# Altium schematic sheet ECU_Comm.SchDoc
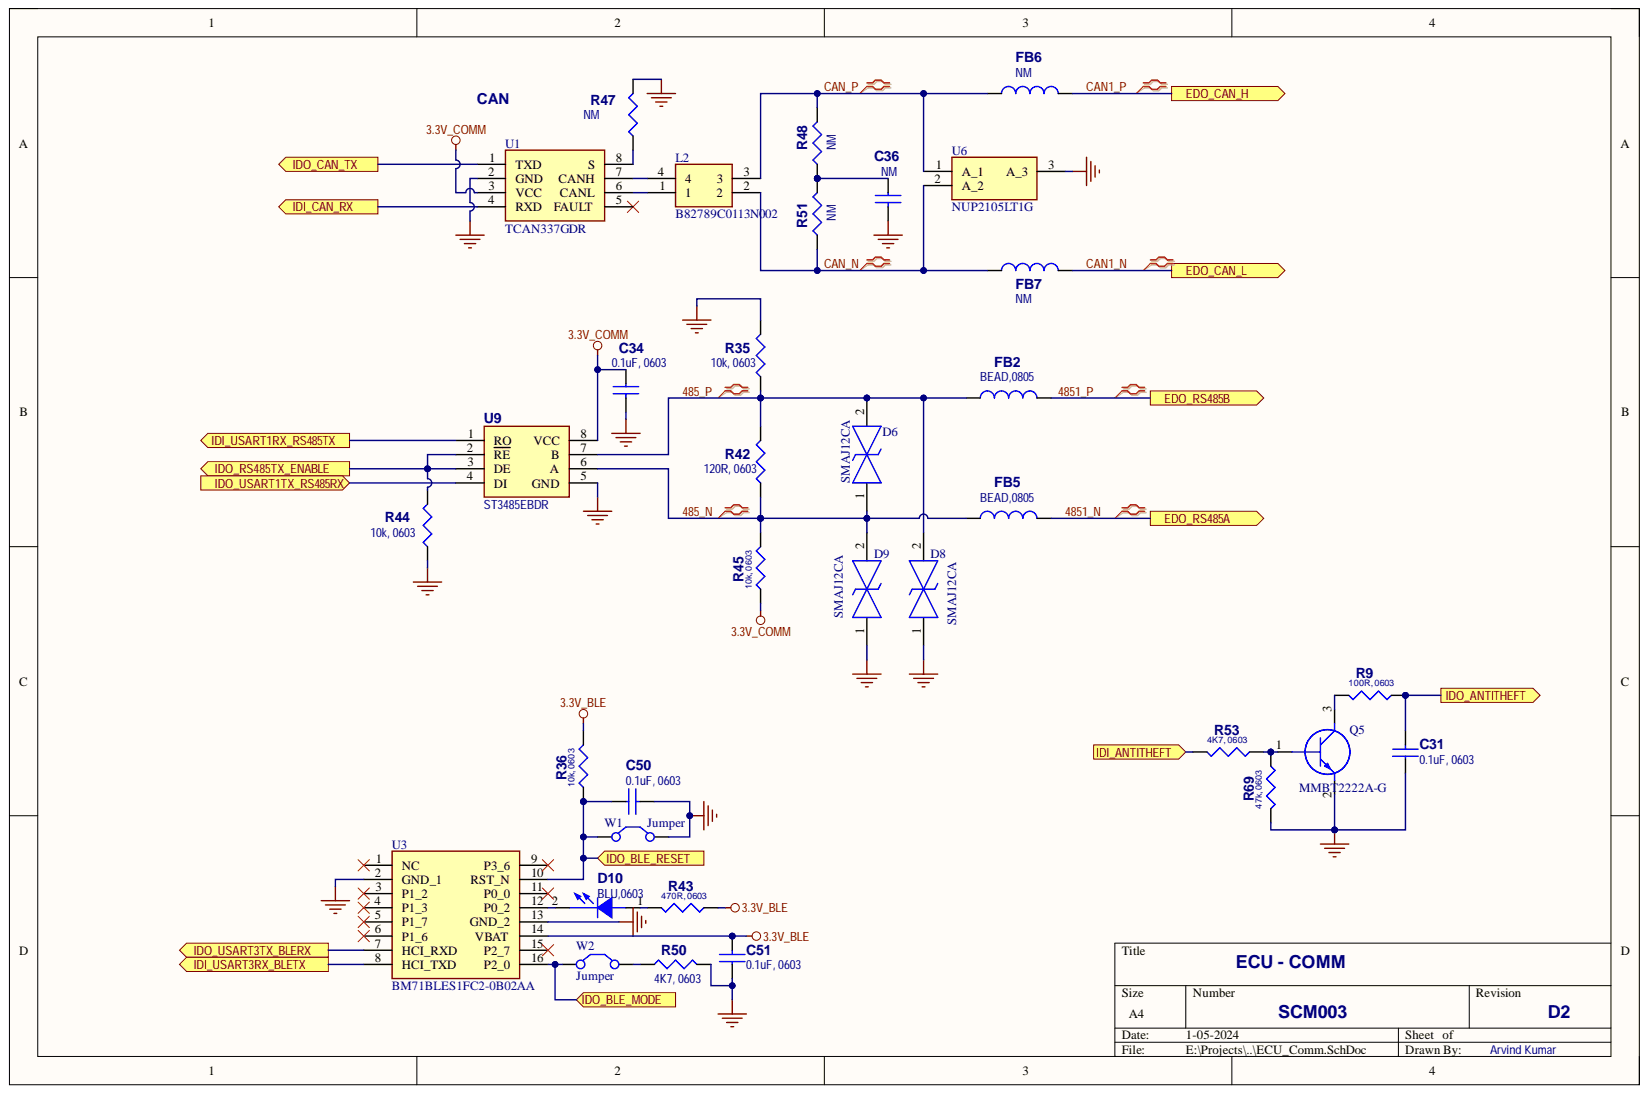
[diagram p0: ECU_Comm.SchDoc]

## Parts
C31  0.1uF, 0603  [C0603]
C34  0.1uF, 0603  [C0603]
C36  NM  [C0603]
C50  0.1uF, 0603  [C0603]
C51  0.1uF, 0603  [C0603]
D6  SMAJ12CA  [DIONM5127X229N]
D8  SMAJ12CA  [DIONM5127X229N]
D9  SMAJ12CA  [DIONM5127X229N]
D10  BLU,0603  [L0603]
FB2  BEAD,0805  [C0805]
FB5  BEAD,0805  [C0805]
FB6  NM  [C0805]
FB7  NM  [C0805]
L2  B82789C0113N002  [B82789C0113N002]
Q5  MMBT2222A-G  [SOT95P240X115-3N]
R9  100R, 0603  [R0603]
R35  10k, 0603  [R0603]
R36  10k, 0603  [R0603]
R42  120R, 0603  [R0603]
R43  470R, 0603  [R0603]
R44  10k, 0603  [R0603]
R45  10k, 0603  [R0603]
R47  NM  [R0603]
R48  NM  [R0603]
R50  4K7, 0603  [R0603]
R51  NM  [R0603]
R53  4K7, 0603  [R0603]
R69  47k, 0603  [R0603]
U1  TCAN337GDR  [SOIC127P600X175-8N]
U3  BM71BLES1FC2-0B02AA  [BM71BLES1FC2_1]
U6  NUP2105LT1G  [SOT96P237X111-3N]
U9  ST3485EBDR  [ST3485BDR]
W1  Jumper  [HDR1X2]
W2  Jumper  [HDR1X2]

## Ports
EDO_CAN_H, EDO_CAN_L, EDO_RS485A, EDO_RS485B, IDI_ANTITHEFT, IDI_CAN_RX, IDI_USART1RX_RS485TX, IDI_USART3RX_BLETX, IDO_ANTITHEFT, IDO_BLE_MODE, IDO_BLE_RESET, IDO_CAN_TX, IDO_RS485TX_ENABLE, IDO_USART1TX_RS485RX, IDO_USART3TX_BLERX

## Nets
3.3V_BLE: C51.2 R36.2 R43.1 U3.14(VBAT)
3.3V_COMM: C34.1 R45.1 U1.3(VCC) U9.8(VCC)
GND_POWER: C31.1 C34.2 C36.2 C50.2 C51.1 D8.1 D9.1 Q5.2 R35.2 R44.1 R47.2 R50.2 R69.2 U1.2(GND) U3.2(GND_1) U3.13(GND_2) U6.3 U9.5(GND) W1.2
485_N: D6.1 D9.2 FB5.1 R42.1 R45.2 U9.6(A)
485_P: D6.2 D8.2 FB2.1 R35.1 R42.2 U9.7(B)
4851_N: FB5.2 ; port EDO_RS485A
4851_P: FB2.2 ; port EDO_RS485B
CAN1_N: FB7.2 ; port EDO_CAN_L
CAN1_P: FB6.2 ; port EDO_CAN_H
CAN_N: FB7.1 L2.2 R51.1 U6.2
CAN_P: FB6.1 L2.3 R48.2 U6.1
IDI_ANTITHEFT: R53.2 ; port IDI_ANTITHEFT
IDI_CAN_RX: U1.4(RXD) ; port IDI_CAN_RX
IDI_USART1RX_RS485TX: U9.1(RO) ; port IDI_USART1RX_RS485TX
IDI_USART3RX_BLETX: U3.8(HCI_TXD) ; port IDI_USART3RX_BLETX
IDO_ANTITHEFT: C31.2 R9.1 ; port IDO_ANTITHEFT
IDO_BLE_MODE: U3.16(P2_0) W2.1 ; port IDO_BLE_MODE
IDO_BLE_RESET: C50.1 R36.1 U3.10(RST_N) W1.1 ; port IDO_BLE_RESET
IDO_CAN_TX: U1.1(TXD) ; port IDO_CAN_TX
IDO_RS485TX_ENABLE: R44.2 U9.2(RE) U9.3(DE) ; port IDO_RS485TX_ENABLE
IDO_USART1TX_RS485RX: U9.4(DI) ; port IDO_USART1TX_RS485RX
IDO_USART3TX_BLERX: U3.7(HCI_RXD) ; port IDO_USART3TX_BLERX
NetC36_1: C36.1 R48.1 R51.2
NetD10_1: D10.1 R43.2
NetD10_2: D10.2 U3.12(P0_2)
NetL2_1: L2.1 U1.6(CANL)
NetL2_4: L2.4 U1.7(CANH)
NetQ5_1: Q5.1 R53.1 R69.1
NetQ5_3: Q5.3 R9.2
NetR47_1: R47.1 U1.8(S)
NetR50_1: R50.1 W2.2

Not connected: U1 5(FAULT); U3 1(NC) 3(P1_2) 4(P1_3) 5(P1_7) 6(P1_6) 9(P3_6) 11(P0_0) 15(P2_7)

## Library data of the parts
C31 C34 C50 C51 = CAP NP_Dup1: Component Kind: Standard
C36 = CAP NP: Component Kind: Standard
D6 D8 D9 = SMAJ12CA: TVS Diode (Bi-directional); Manufacturer_Name: Bourns; Arrow Part Number: SMAJ12CA; Arrow Price/Stock: https://www.arrow.com/en/products/smaj12ca/bourns?region=nac; Component Kind: Standard; Datasheet Link: https://www.bourns.com/data/global/pdfs/SMAJ.pdf; Height: 2.29mm; Manufacturer_Part_Number: SMAJ12CA; Mouser Part Number: 652-SMAJ12CA; Mouser Price/Stock: https://www.mouser.co.uk/ProductDetail/Bourns/SMAJ12CA?qs=A3H9pljuJ8QXtI4Ziid8eA%3D%3D
D10 = LED: Component Kind: Standard
FB2 FB5-FB7 = INDUCTOR: Component Kind: Standard
L2 = B82789C0113N002: Inductor; Manufacturer_Name: TDK; Arrow Part Number: B82789C0113N002; Arrow Price/Stock: https://www.arrow.com/en/products/b82789c0113n002/epcos-tdk; Component Kind: Standard; Datasheet Link: https://product.tdk.com/system/files/dam/doc/product/emc/emc/cmf_cmc/data_sheet/30/db/ind_2008/b82789c0_s0.pdf; Manufacturer_Part_Number: B82789C0113N002; Mouser Part Number: 871-B82789C0113N002; Mouser Price/Stock: https://www.mouser.co.uk/ProductDetail/EPCOS-TDK/B82789C0113N002?qs=pGJ4H8VyKtVDhemEKPOqNw%3D%3D
Q5 = MMBT2222A-G: Transistor BJT NPN; Manufacturer_Name: Comchip Technology; Arrow Part Number: MMBT2222A-G; Arrow Price/Stock: https://www.arrow.com/en/products/mmbt2222a-g/comchip-technology?region=nac; Component Kind: Standard; Datasheet Link: https://datasheet.datasheetarchive.com/originals/distributors/Datasheets-7/DSA-138996.pdf; Height: 1.15mm; Manufacturer_Part_Number: MMBT2222A-G; Mouser Part Number: 750-MMBT2222A-G; Mouser Price/Stock: https://www.mouser.co.uk/ProductDetail/Comchip-Technology/MMBT2222A-G?qs=2qJf6qQ4IOIM%2FSE9iCL8gQ%3D%3D
R9 R35 R36 R42-R45 R53 R69 = R: Component Kind: Standard
R47 R48 R51 = R_Dup2: Component Kind: Standard
R50 = R_Dup1: Component Kind: Standard
U1 = TCAN337GDR: Integrated Circuit; Manufacturer_Name: Texas Instruments; Arrow Part Number: TCAN337GDR; Arrow Price/Stock: https://www.arrow.com/en/products/tcan337gdr/texas-instruments?region=nac; Component Kind: Standard; Datasheet Link: http://www.ti.com/lit/gpn/tcan337g; Height: 1.75mm; Manufacturer_Part_Number: TCAN337GDR; Mouser Part Number: 595-TCAN337GDR; Mouser Price/Stock: https://www.mouser.co.uk/ProductDetail/Texas-Instruments/TCAN337GDR?qs=gMfM3zrbS4qJ%252BEWbPaeUtA%3D%3D
U3 = BM71BLES1FC2-0B02AA: Undefined or Miscellaneous; Manufacturer_Name: Microchip; Arrow Part Number: BM71BLES1FC2-0B02AA; Arrow Price/Stock: https://www.arrow.com/en/products/bm71bles1fc2-0b02aa/microchip-technology?region=nac; Component Kind: Standard; Datasheet Link: http://www.microchip.com/mymicrochip/filehandler.aspx?ddocname=en578477; Manufacturer_Part_Number: BM71BLES1FC2-0B02AA; Mouser Part Number: 579-71BLES1FC20B02AA; Mouser Price/Stock: https://www.mouser.co.uk/ProductDetail/Microchip-Technology/BM71BLES1FC2-0B02AA?qs=Mv7BduZupUinoQmB%252BTgbww%3D%3D
U6 = NUP2105LT1G: Integrated Circuit; Manufacturer_Name: onsemi; Arrow Part Number: NUP2105LT1G; Arrow Price/Stock: https://www.arrow.com/en/products/nup2105lt1g/on-semiconductor?region=nac; Component Kind: Standard; Datasheet Link: https://www.onsemi.com/pub/Collateral/NUP2105L-D.PDF; Height: 1.11mm; Manufacturer_Part_Number: NUP2105LT1G; Mouser Part Number: 863-NUP2105LT1G; Mouser Price/Stock: https://www.mouser.co.uk/ProductDetail/onsemi/NUP2105LT1G?qs=ZXBb0xZ9WeDHmiHN%252BYKtYA%3D%3D
U9 = ST485BDR: Integrated Circuit; Manufacturer_Name: STMicroelectronics; Arrow Part Number: ST485BDR; Arrow Price/Stock: https://www.arrow.com/en/products/st485bdr/stmicroelectronics; Component Kind: Standard; Datasheet Link: http://www.st.com/st-web-ui/static/active/en/resource/technical/document/datasheet/CD00002183.pdf; Height: 1.75mm; Manufacturer_Part_Number: ST485BDR; Mouser Part Number: 511-ST485BDR; Mouser Price/Stock: https://www.mouser.co.uk/ProductDetail/STMicroelectronics/ST485BDR?qs=I4eBWbnKbTjsYS7Ohlsv0w%3D%3D
W1 W2 = Jumper: Jumper Wire; Component Kind: Standard; LatestRevisionDate: 17-Jul-2002; LatestRevisionNote: Re-released for DXP Platform.; Library Name: Miscellaneous Devices.IntLib; PackageDescription: Radial Cap, Thru-Hole; 2 Leads; 0.2 in Pin Spacing; PackageReference: RAD-0.2; Published: 24-Mar-1999; Publisher: Altium Limited
